# Altium schematic sheet pioneer_2-bluepill_eletronic_wrapper-supply.SchDoc(U_pioneer_2-bluepill_eletronic_wrapper-supply)
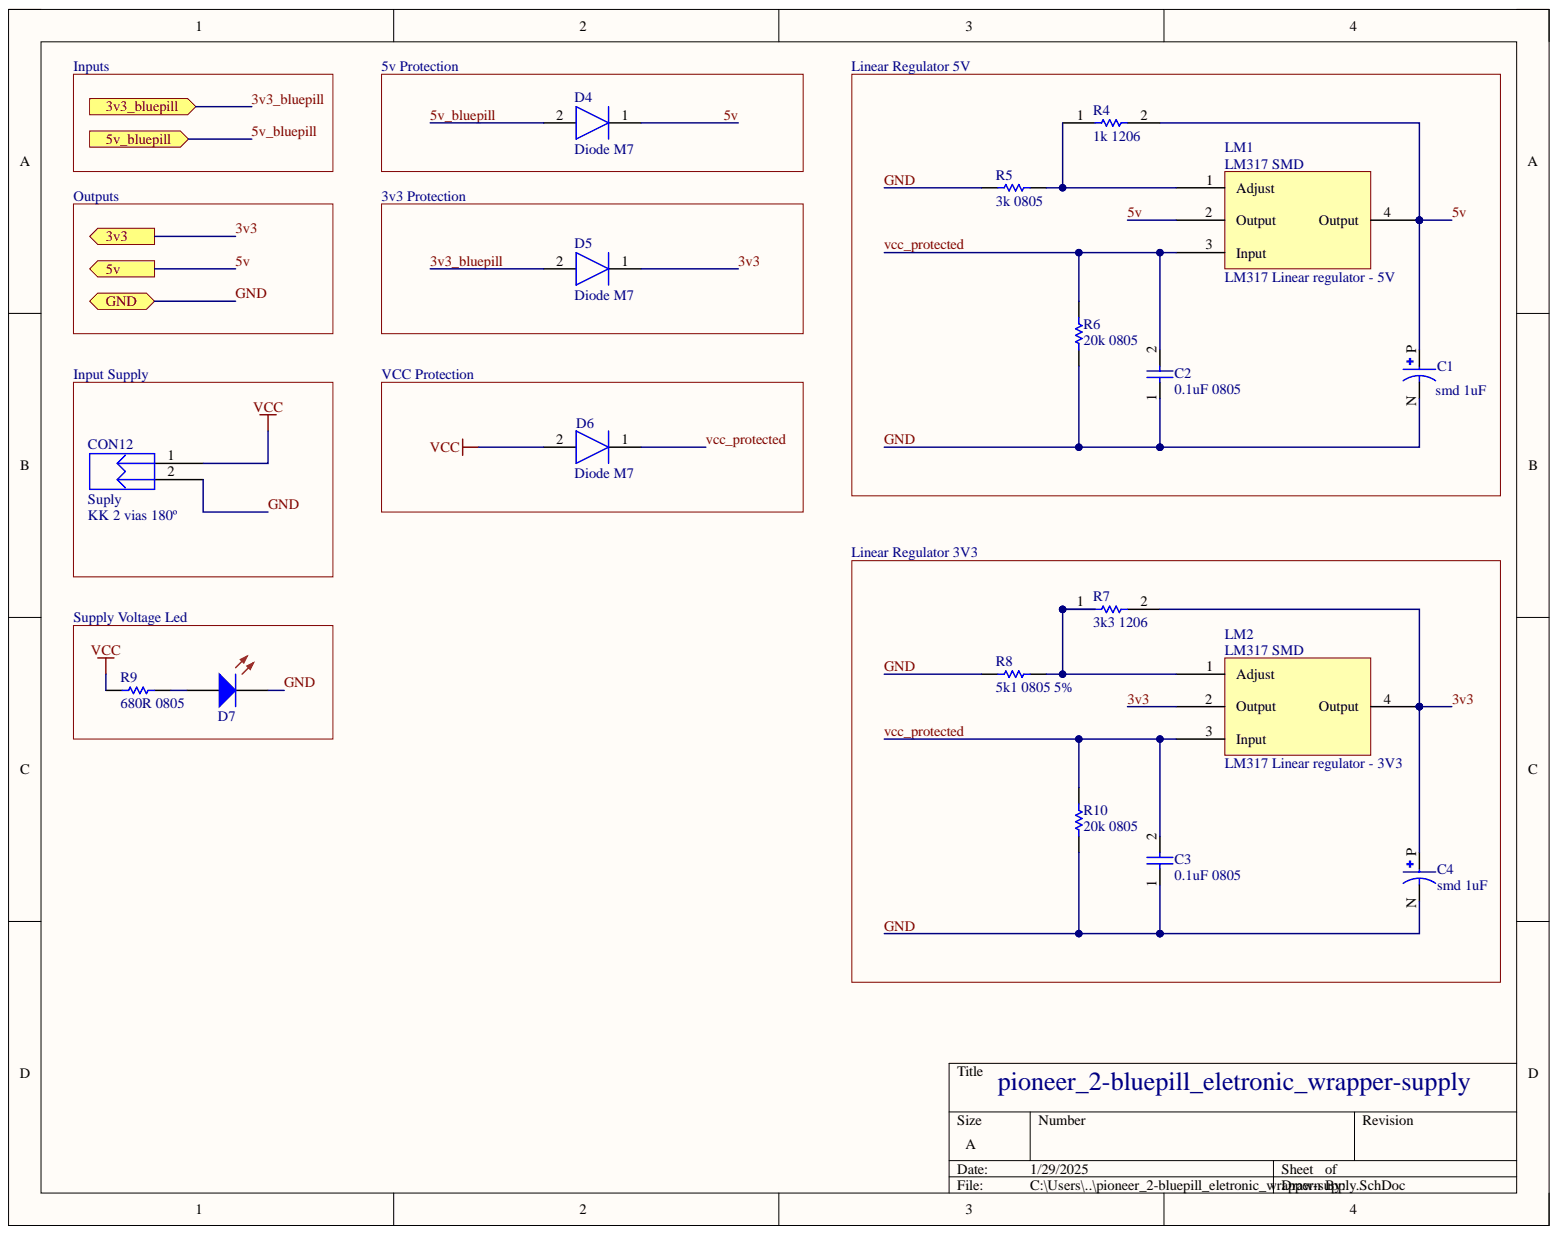
[diagram p0: pioneer_2-bluepill_eletronic_wrapper-supply.SchDoc]

## Parts
C1  smd 1uF  [CAP_EEE1HA2R2SR]
C2  0.1uF 0805  [CAPACITOR 0805]
C3  0.1uF 0805  [CAPACITOR 0805]
C4  smd 1uF  [CAP_EEE1HA2R2SR]
CON12  Suply  Valor: KK 2 vias 180º  [KK_2VIAS_180º]
D4  Diode M7  MP: M7  [DIOM505270X240]
D5  Diode M7  MP: M7  [DIOM505270X240]
D6  Diode M7  MP: M7  [DIOM505270X240]
D7  LED 3MM RED  [LED RED]  (value not drawn)
LM1  LM317 Linear regulator - 5V  [SOT-223-2]
LM2  LM317 Linear regulator - 3V3  [SOT-223-2]
R4  1k 1206  [RESC3216X60N]
R5  3k 0805  [RESISTOR 0805]
R6  20k 0805  [RESISTOR 0805]
R7  3k3 1206  [RESC3216X60N]
R8  5k1 0805 5%  [RESISTOR 0805]
R9  680R 0805  [RESISTOR 0805]
R10  20k 0805  [RESISTOR 0805]

## Ports
3v3, 3v3_bluepill, 5v, 5v_bluepill, GND

## Nets
VCC: CON12.1 D6.2 R9.2
3v3: C4.P D5.1 LM2.2(Output) LM2.4(Output) R7.2 ; port 3v3
3v3_bluepill: D5.2 ; port 3v3_bluepill
5v: C1.P D4.1 LM1.2(Output) LM1.4(Output) R4.2 ; port 5v
5v_bluepill: D4.2 ; port 5v_bluepill
GND: C1.N C2.1 C3.1 C4.N CON12.2 D7.2 R5.2 R6.2 R8.1 R10.2 ; port GND
vcc_protected: C2.2 C3.2 D6.1 LM1.3(Input) LM2.3(Input) R6.1 R10.1
NetD7_1: D7.1 R9.1
NetLM1_1: LM1.1(Adjust) R4.1 R5.1
NetLM2_1: LM2.1(Adjust) R7.1 R8.2

## Library data of the parts
C1 C4 = Cap. Elet. smd 1uF 50V: Aluminum Organic Polymer Capacitors smd 50volts 1uF; Manufacturer_Name: Panasonic; Manufacturer_Part_Number: 16SEPC470M; Arrow Part Number: 16SEPC470M; Arrow Price/Stock: https://www.arrow.com/en/products/16sepc470m/panasonic; Component Kind: Standard; Datasheet Link: http://industrial.panasonic.com/www-cgi/jvcr13pz.cgi?E+CI+4+AAB8010+16SEPC470M+8+WW; Height: 13mm; Mouser Part Number: 667-16SEPC470M; Mouser Price/Stock: https://www.mouser.co.uk/ProductDetail/Panasonic/16SEPC470M?qs=OE1iw1LrrPEdZuWyF2l%252B5w%3D%3D; Library Name: pioneer_2-bluepill_eletronic_wrapper.SchLib
C2 C3 = CAP 0805: capacitor 0805; Manufacturer: PANASONIC ELECTRONIC COMPONENTS; Manufacturer Part Number: ERJ-3GEY0R00V; Brand: Panasonic; Power (Watts): 0.1W, 1/10W; Power Rating: 100; Product Range: AEC-Q200 ERJ3; Qualification: AEC-Q200; Resistance: 0; Resistance (Ohms): 0.0; Resistor Case Style: 0603 [1608 Metric]; Tolerance: Jumper; Type: Thick Film Resistors SMD; Voltage Rating: 75; Case Code - in: 0603; Case Code - mm: 1608; Category: Resistors; Component Kind: Standard; ComponentLink1Description: http://www.farnell.com/cad/1697908.zip; ComponentLink1URL: http://www.farnell.com/cad/1697908.zip; ComponentLink2Description: http://www.farnell.com/datasheets/1678796.pdf; ComponentLink2URL: http://www.farnell.com/datasheets/1678796.pdf; ComponentLink3Description: http://industrial.panasonic.com/www-cgi/jvcr13pz.cgi?E+PZ+3+AOA0001+ERJ3GEY0R00V+7+WW; ComponentLink3URL: http://industrial.panasonic.com/www-cgi/jvcr13pz.cgi?E+PZ+3+AOA0001+ERJ3GEY0R00V+7+WW; ComponentLink4Description: http://www.mouser.com/ds/2/315/AOA0000C84-947596.pdf; ComponentLink4URL: http://www.mouser.com/ds/2/315/AOA0000C84-947596.pdf; Composition: Thick Film; Features: Automotive AEC-Q200; Height: 0.022" (0.55mm); Length: 1.6 mm; Minimum Order Quantity: 1; MSL: -; Number of Terminations: 2; Operating Temperature: -55°C ~ 155°C; Operating Temperature Range: - 55 C to + 155 C; Package / Case: 0603 (1608 Metric); Packaging: Cut Tape; Pricing 1: 1=0.160, 10=0.019, 100=0.013, 500=0.010, 1000=0.005, 5000=0.003 (AUD); Product: Thick Film Resistors Zero-Ohm; Reeling: True; Resistance Tolerance: -; RoHS: YES; RoHS - Mouser: E; Series: ERJ; Size / Dimension: 0.063" L x 0.031" W (1.60mm x 0.80mm); Stock 1: 1987085; Supplier 1: Mouser; Supplier Device Package: 0603; Supplier Part Number 1: 667-ERJ-3GEY0R00V; Temperature Coefficient: -; Termination Style: SMD/SMT; Width: 0.8 mm; Library Name: pioneer_2-bluepill_eletronic_wrapper.SchLib
CON12 = KK_2.54_2vias: Conector KK 2.54mm 2 vias; Descrição: Conector KK 3 vias com passo 2.54mm; Encapsulamento: PTH - 180º; Modelo: 2 vias - 180º; Component Kind: Standard; Library Name: pioneer_2-bluepill_eletronic_wrapper.SchLib
D4-D6 = Diode M7: Diode, SMA, 1000V, 1A, 150°C; MF: Diotec Semiconductor; Check_prices: https://www.snapeda.com/parts/M7/Diotec/view-part/?ref=eda; Component Kind: Standard; Package: DO-214AC Diotec; SnapEDA_Link: https://www.snapeda.com/parts/M7/Diotec/view-part/?ref=snap; Library Name: pioneer_2-bluepill_eletronic_wrapper.SchLib
D7 = LED 3MM RED: Manufacturer: Paralight; Manufacturer Part Number: IMP585; Current If Luminous Intensity: 2; Dominant Wavelength: 625; External Diameter: 2.9; External Length / Height: 4.6; Forward Current If: 20; Forward Voltage VF Max: 2.5; Lead Cross-section Depth: 0.5; LED / Lamp Size: 3mm / T-1; LED Mounting: Through Hole; LED Type: Standard; Lens Shape: Round; Luminous Intensity: 3; Luminous Intensity @ If Min: 0.7; Peak Forward Current: 7; PIV Max: 5; SVHC: No SVHC (15-Jun-2015); Viewing Angle: 60°; Voltage Vf Typ: 2.2V<!--FANDB--><div class="features-and-benefits-container"><div class="features-and-benefits-description"><span class="features-benefits-value">The L-934LID from Kingbright is a through hole round shape high efficiency red colour LED. The device is made of gallium arsenide phosphide on gallium phosphide orange light emitting diode. This LED is widely used because of low power consumption, long life and solid state reliability.</span></div><div class="features-and-benefits-list"><ul class="features-and-benefits-list-list"><li class="features-and-benefits-list-item">Standard bulb size of T-1 (3mm)</li><li class="features-and-benefits-list-item">Reliable and rugged</li><li class="features-and-benefits-list-item">Viewing angle is 60°</li><li class="features-and-benefits-list-item">Luminous intensity of 3mcd at 2mA</li><li class="features-and-benefits-list-item">Forward voltage is 2.0V</li><li class="features-and-benefits-list-item">Forward current (If) is 20mA</li><li class="features-and-benefits-list-item">Dominant wavelength is 625nm at IF=20mA</li><li class="features-and-benefits-list-item">Peak wavelength is 627nm at IF=20; Bulb Size: 3mm; Component Kind: Standard; ComponentLink1Description: http://loja.multcomercial.com.br/led-3mm-vermelho-difuso-l-314hd-paralight.html; ComponentLink1URL: http://loja.multcomercial.com.br/led-3mm-vermelho-difuso-l-314hd-paralight.html; Description: LED 3MM RED; Forward Current If Max: 20; Forward Voltage: 2; Lead Cross-section Width: 0.5; Lead Spacing: 2.54; LED Colour: Red; Luminous Intensity Typ: 3; Mounting Type: PCB Through Hole; MSL: -; No. of Pins: 2; Operating Temperature Max: 85; Operating Temperature Min: -40; Operating Temperature Range: -40°C to +85; Packaging: Each; Peak Wavelength: 625; Pricing 1: 1=0.15 (BRL); RoHS: YES; Stock 1: 35260; Supplier 1: Mult Comercial; Supplier Part Number 1: Led 3mm Vermelho Difuso L-314HD - Paralight; Wavelength Typ: 625; Library Name: pioneer_2-bluepill_eletronic_wrapper.SchLib
LM1 LM2 = lm317 smd: SOT223; Component Kind: Standard; Library Name: pioneer_2-bluepill_eletronic_wrapper.SchLib
R4 R7 = RES 1206: RES 1206 5%; Manufacturer_Name: Vishay; Manufacturer_Part_Number: CRCW12064K70FKEA; Arrow Part Number: CRCW12064K70FKEA; Arrow Price/Stock: https://www.arrow.com/en/products/crcw12064k70fkea/vishay; Component Kind: Standard; Datasheet Link: http://docs-emea.rs-online.com/webdocs/13ec/0900766b813ecc08.pdf; Height: 0.6mm; Mouser Part Number: 71-CRCW1206-4.7K-E3; Mouser Price/Stock: https://www.mouser.co.uk/ProductDetail/Vishay-Dale/CRCW12064K70FKEA?qs=1vm4qhDHCvlKJmatsKzyFQ%3D%3D; Library Name: pioneer_2-bluepill_eletronic_wrapper.SchLib
R5 R6 R8-R10 = RES 0805 5%: 0805; Manufacturer: PANASONIC ELECTRONIC COMPONENTS; Manufacturer Part Number: ERJ-3GEY0R00V; Brand: Panasonic; Power (Watts): 0.1W, 1/10W; Power Rating: 100; Product Range: AEC-Q200 ERJ3; Qualification: AEC-Q200; Resistance: 0; Resistance (Ohms): 0.0; Resistor Case Style: 0603 [1608 Metric]; Tolerance: Jumper; Voltage Rating: 75; Case Code - in: 0603; Case Code - mm: 1608; Category: Resistors; Component Kind: Standard; ComponentLink1Description: http://www.farnell.com/cad/1697908.zip; ComponentLink1URL: http://www.farnell.com/cad/1697908.zip; ComponentLink2Description: http://www.farnell.com/datasheets/1678796.pdf; ComponentLink2URL: http://www.farnell.com/datasheets/1678796.pdf; ComponentLink3Description: http://industrial.panasonic.com/www-cgi/jvcr13pz.cgi?E+PZ+3+AOA0001+ERJ3GEY0R00V+7+WW; ComponentLink3URL: http://industrial.panasonic.com/www-cgi/jvcr13pz.cgi?E+PZ+3+AOA0001+ERJ3GEY0R00V+7+WW; ComponentLink4Description: http://www.mouser.com/ds/2/315/AOA0000C84-947596.pdf; ComponentLink4URL: http://www.mouser.com/ds/2/315/AOA0000C84-947596.pdf; Composition: Thick Film; Features: Automotive AEC-Q200; Height: 0.022" (0.55mm); Length: 1.6 mm; Minimum Order Quantity: 1; MSL: -; Number of Terminations: 2; Operating Temperature: -55°C ~ 155°C; Operating Temperature Range: - 55 C to + 155 C; Package / Case: 0603 (1608 Metric); Packaging: Cut Tape; Pricing 1: 1=0.160, 10=0.019, 100=0.013, 500=0.010, 1000=0.005, 5000=0.003 (AUD); Product: Thick Film Resistors Zero-Ohm; Reeling: True; Resistance Tolerance: -; RoHS: YES; RoHS - Mouser: E; Series: ERJ; Size / Dimension: 0.063" L x 0.031" W (1.60mm x 0.80mm); Stock 1: 1987085; Supplier 1: Mouser; Supplier Device Package: 0603; Supplier Part Number 1: 667-ERJ-3GEY0R00V; Temperature Coefficient: -; Termination Style: SMD/SMT; Type: Thick Film Resistors SMD; Width: 0.8 mm; Library Name: pioneer_2-bluepill_eletronic_wrapper.SchLib
